# Altium schematic sheet 40M_REF.SchDoc
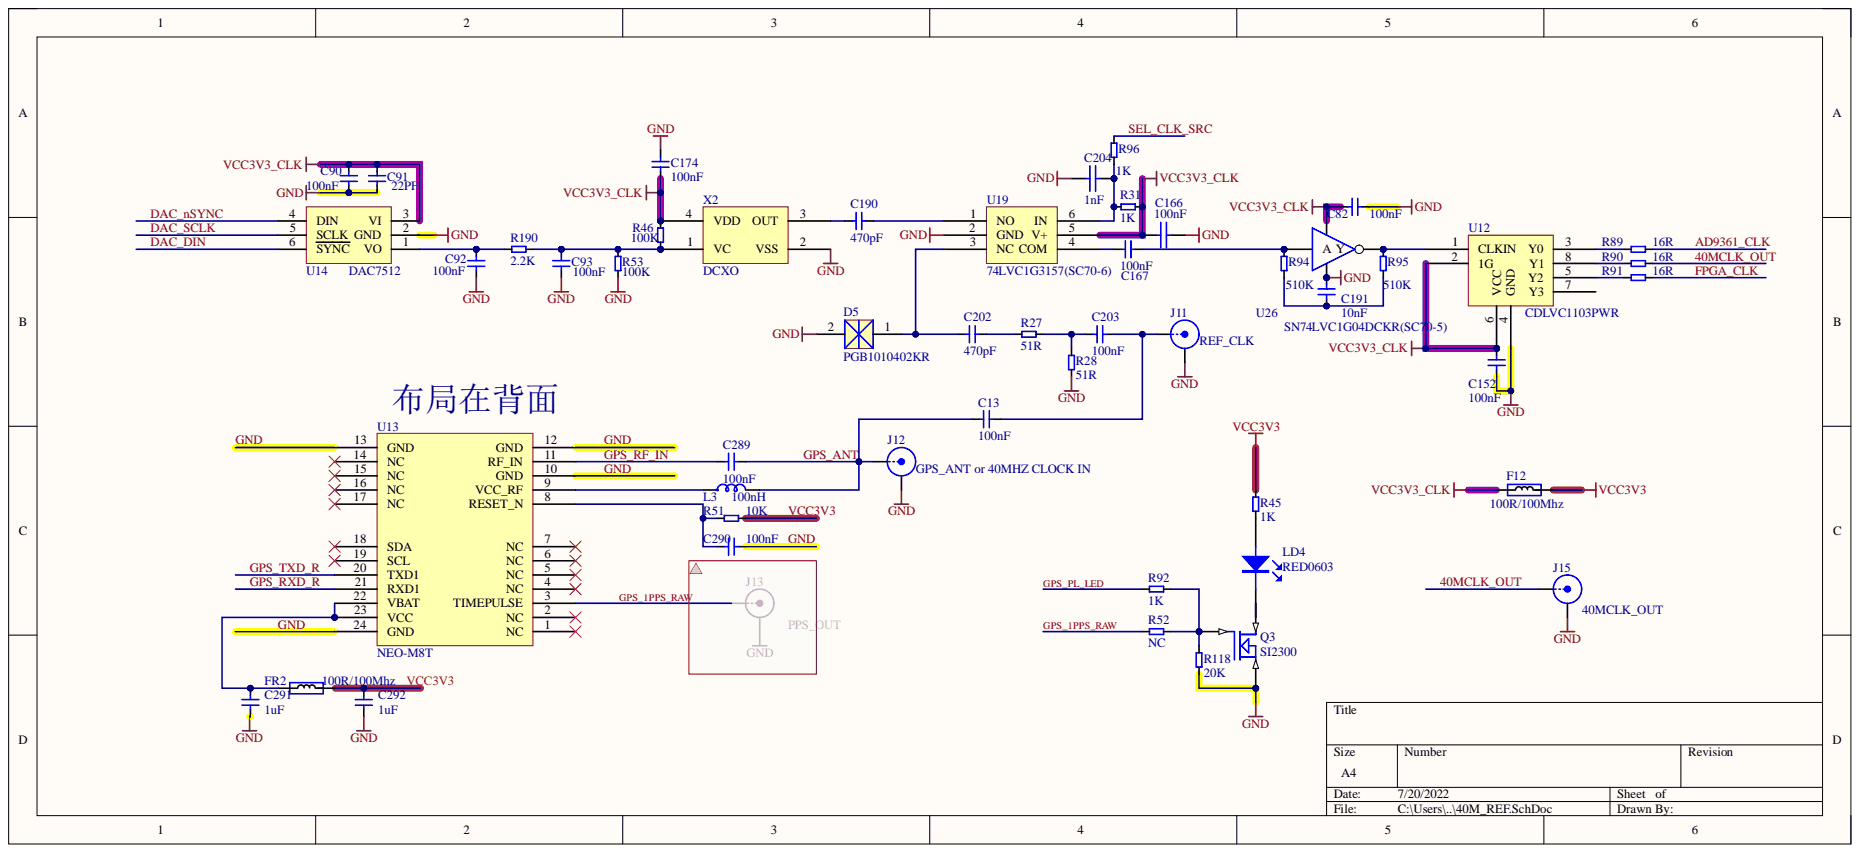
[diagram p0: 40M_REF.SchDoc]

## Parts
C13  100nF  [C0402]
C82  100nF  [C0201]
C90  100nF  [C0201]
C91  22PF  [C0201]
C92  100nF  [C0201]
C93  100nF  [C0201]
C152  100nF  [C0201]
C166  100nF  [CAP0402]
C167  100nF  [C0201]
C174  100nF  [C0201]
C190  470pF  [C0201]
C191  10nF  [C0201]
C202  470pF  [C0201]
C203  100nF  [C0201]
C204  1nF  [CAP0402]
C289  100nF  [C0402]
C290  100nF  [C0201]
C291  1uF  [C0402]
C292  1uF  [C0402]
D5  PGB1010402KR  [R0402]
F12  100R/100Mhz  [L0402]
FR2  100R/100Mhz  [L0402]
J11  REF_CLK  [MMCX_UFL_CONN_U]
J12  GPS_ANT or 40MHZ CLOCK IN  [RF_SMA]
J15  40MCLK_OUT  [MMCX_UFL_CONN_U]
L3  100nH  [L0402]
LD4  RED0603  [LED0402]
Q3  SI2300  [SOT95P240X110-3N]
R27  51R  [R0201]
R28  51R  [R0201]
R31  1K  [R0201]
R45  1K  [R0201]
R46  100K  [R0201]
R51  10K  [R0201]
R52  NC  [R0201]
R53  100K  [R0201]
R89  16R  [R0201]
R90  16R  [R0201]
R91  16R  [R0201]
R92  1K  [R0201]
R94  510K  [R0201]
R95  510K  [R0201]
R96  1K  [R0201]
R118  20K  [R0201]
R190  2.2K  [R0201]
U12  CDLVC1103PWR  [TSSOP8]
U13  NEO-M8T  [UBLOX8]
U14  DAC7512  [SOT23-6]
U19  74LVC1G3157(SC70-6)  [SC-70-6]
U26  SN74LVC1G04DCKR(SC70-5)  [SC-70-5]
X2  DCXO  [OSC_3225]

## Nets
VCC3V3_CLK: C82.2 C90.2 C91.2 C152.2 C166.1 C174.1 F12.1 R31.1 R46.1 U12.2(1G) U12.6(VCC) U14.3(VI) U19.5(V+) U26.5(AY) X2.4(VDD)
40MCLK_OUT: J15.1 R90.1
AD9361_CLK: R89.1
DAC_DIN: U14.6(SYNC)
DAC_nSYNC: U14.4(DIN)
DAC_SCLK: U14.5(SCLK)
FPGA_CLK: R91.1
GND: C82.1 C90.1 C91.1 C92.1 C93.1 C152.1 C166.2 C174.2 C191.2 C204.2 C290.1 C291.2 C292.1 D5.2 J11.2 J12.2 J15.2 Q3.2 R28.2 R53.2 R118.1 U12.4(GND) U13.10(GND) U13.12(GND) U13.13(GND) U13.24(GND) U14.2(GND) U19.2(GND) U26.3 X2.2(VSS)
GPS_1PPS_RAW: R52.2 U13.3(TIMEPULSE)
GPS_ANT: C13.1 C289.1 J12.1 L3.2
GPS_PL_LED: R92.2
GPS_RF_IN: C289.2 U13.11(RF_IN)
GPS_RXD_R: U13.21(RXD1)
GPS_TXD_R: U13.20(TXD1)
SEL_CLK_SRC: R96.2
VCC3V3: C292.2 F12.2 FR2.2 R45.2 R51.1
NetC13_2: C13.2 C203.2 J11.1
NetC92_2: C92.2 R190.1 U14.1(VO)
NetC93_2: C93.2 R46.2 R53.1 R190.2 X2.1(VC)
NetC167_1: C167.1 U19.4(COM)
NetC167_2: C167.2 R94.2 U26.2
NetC190_1: C190.1 U19.1(NO)
NetC190_2: C190.2 X2.3(OUT)
NetC191_1: C191.1 R94.1 R95.1
NetC202_1: C202.1 D5.1 U19.3(NC)
NetC202_2: C202.2 R27.1
NetC203_1: C203.1 R27.2 R28.1
NetC204_1: C204.1 R31.2 R96.1 U19.6(IN)
NetC290_2: C290.2 R51.2 U13.8(RESET_N)
NetC291_1: C291.1 FR2.1 U13.22(VBAT) U13.23(VCC)
NetL3_1: L3.1 U13.9(VCC_RF)
NetLD4_1: LD4.1 Q3.3
NetLD4_2: LD4.2 R45.1
NetQ3_1: Q3.1 R52.1 R92.1 R118.2
NetR89_2: R89.2 U12.3(Y0)
NetR90_2: R90.2 U12.8(Y1)
NetR91_2: R91.2 U12.5(Y2)
NetR95_2: R95.2 U12.1(CLKIN) U26.4

Not connected: U12 7(Y3); U13 1(NC) 2(NC) 4(NC) 5(NC) 6(NC) 7(NC) 14(NC) 15(NC) 16(NC) 17(NC) 18(SDA) 19(SCL)

## Library data of the parts
C13 C82 C90-C93 C152 C167 C174 C190 C191 C202 C203 C289-C292 = C: Capacitor; Component Kind: Standard; Library Name: 电容-SCH.LIB
C166 C204 = CAP: Capacitor; Component Kind: Standard
D5 = PGB1010402KR: 无极性保护管; Component Kind: Standard; Library Name: b200mini.SchLib
F12 = Ferrite: Manufacturer: TDK Corporation; Manufacturer Part Number 1: MPZ2012S601AT000; Part Description: FERRITE BEAD 600 OHM 0805 1LN; Current Rating: 2A; DC Resistance (DCR): 100 mOhm Max; Filter Type: Differential Mode - Single; Impedance @ Frequency: 600 Ohm @ 100MHz; Value: 600R, 2A; Category: Filters; Component Kind: Standard; Height (Max): 0.041" (1.05mm); Mounting Type: Surface Mount; Package / Case: 0805 (2012 Metric); Packaging: Tape & Reel (TR); RoHS: RoHS Compliant; Size / Dimension: 0.079" L x 0.049" W (2.00mm x 1.25mm); Supplier 1: Digi-Key; Supplier Part Number 1: 445-2206-2-ND; Library Name: LimeMicroAltiumLib_schLib.SchLib
FR2 = Ferrite: Manufacturer: Taiyo Yuden; Manufacturer Part Number 1: BK0603TS601-T; Part Description: FERRITE BEAD 600 OHM 0201 1LN; Current Rating: 270mA; DC Resistance (DCR): 750 mOhm Max; Filter Type: Differential Mode - Single; Impedance @ Frequency: 600 Ohm @ 100MHz; Value: 600R, 0.27A; Category: Filters; Component Kind: Standard; Height (Max): 0.013" (0.33mm); Mounting Type: Surface Mount; Package / Case: 0201 (0603 Metric); Packaging: Cut Tape (CT); RoHS: RoHS Compliant; Size / Dimension: 0.024" L x 0.012" W (0.60mm x 0.30mm); Supplier 1: Digi-Key; Supplier Part Number 1: 587-3277-1-ND; Library Name: GPSDO.SCHLIB
J11 J12 J15 = RF_IF_connector: CONN UMC RCPT STR 50 OHM SMD; Manufacturer: Molex, LLC; Manufacturer Part Number 1: 0734120110; Body Finish: Nickel; Body Material: Phosphor Bronze; Center Contact Material: Brass; Center Contact Plating: Gold; Connector Style: Ultra Miniature Coaxial; Connector Type: Jack, Male Pin; Contact Termination: Solder; Dielectric Material: Liquid Crystal Polymer (LCP); Frequency - Max: 6GHz; Impedance: 50 Ohm; Voltage Rating: 60V; Cable Group: -; Category: Connectors, Interconnects; Component Kind: Standard; Fastening Type: Snap-On; Features: -; Housing Color: Silver; Ingress Protection: -; Mounting Type: Surface Mount; Operating Temperature: -40°C ~ 90°C; Packaging: Cut Tape (CT); Part Description: CONN UMC RCPT STR 50 OHM SMD; RoHS: RoHS Compliant; Shield Termination: Solder; Supplier 1: Digi-Key; Supplier Part Number 1: WM5587CT-ND; Library Name: LimeMicroAltiumLib_schLib.SchLib
L3 = INDUCTOR1_1: Inductor; Component Kind: Standard; Library Name: 常用.SchLib
LD4 = LED_12: Component Kind: Standard; Library Name: zc706_2_0.SCHLIB
Q3 = SI2301_2: N-MOS SOT23; Component Kind: Standard; Library Name: zc706_2_0_1.SCHLIB
R27 R28 R31 R45 R46 R51-R53 R89-R92 R94-R96 R118 R190 = RES2: Component Kind: Standard
  R27 R28 R31 R45 R46 R52 R53 R89-R92 R94-R96 R118 R190: Library Name: 电阻-SCH.LIB
  R51: Library Name: UBX.SCHLIB
U13 = HT1612Z3M3L: GPS模块型号是NEO-M8T; Component Kind: Standard; Library Name: GPSDO.SCHLIB
U19 = Component_1: 单刀双投模拟开关; Component Kind: Standard
U26 = SN74LVC1G04DCKR: Component Kind: Standard
U12 = CDLVC1103PWR: Component Kind: Standard; Library Name: PCB_Project.SCHLIB
U14 = DAC7512: Component Kind: Standard; Library Name: V6_130_CFG.SCHLIB
X2 = DCXO: Component Kind: Standard; Library Name: b200mini.SchLib
